# Altium schematic sheet pwr.SchDoc
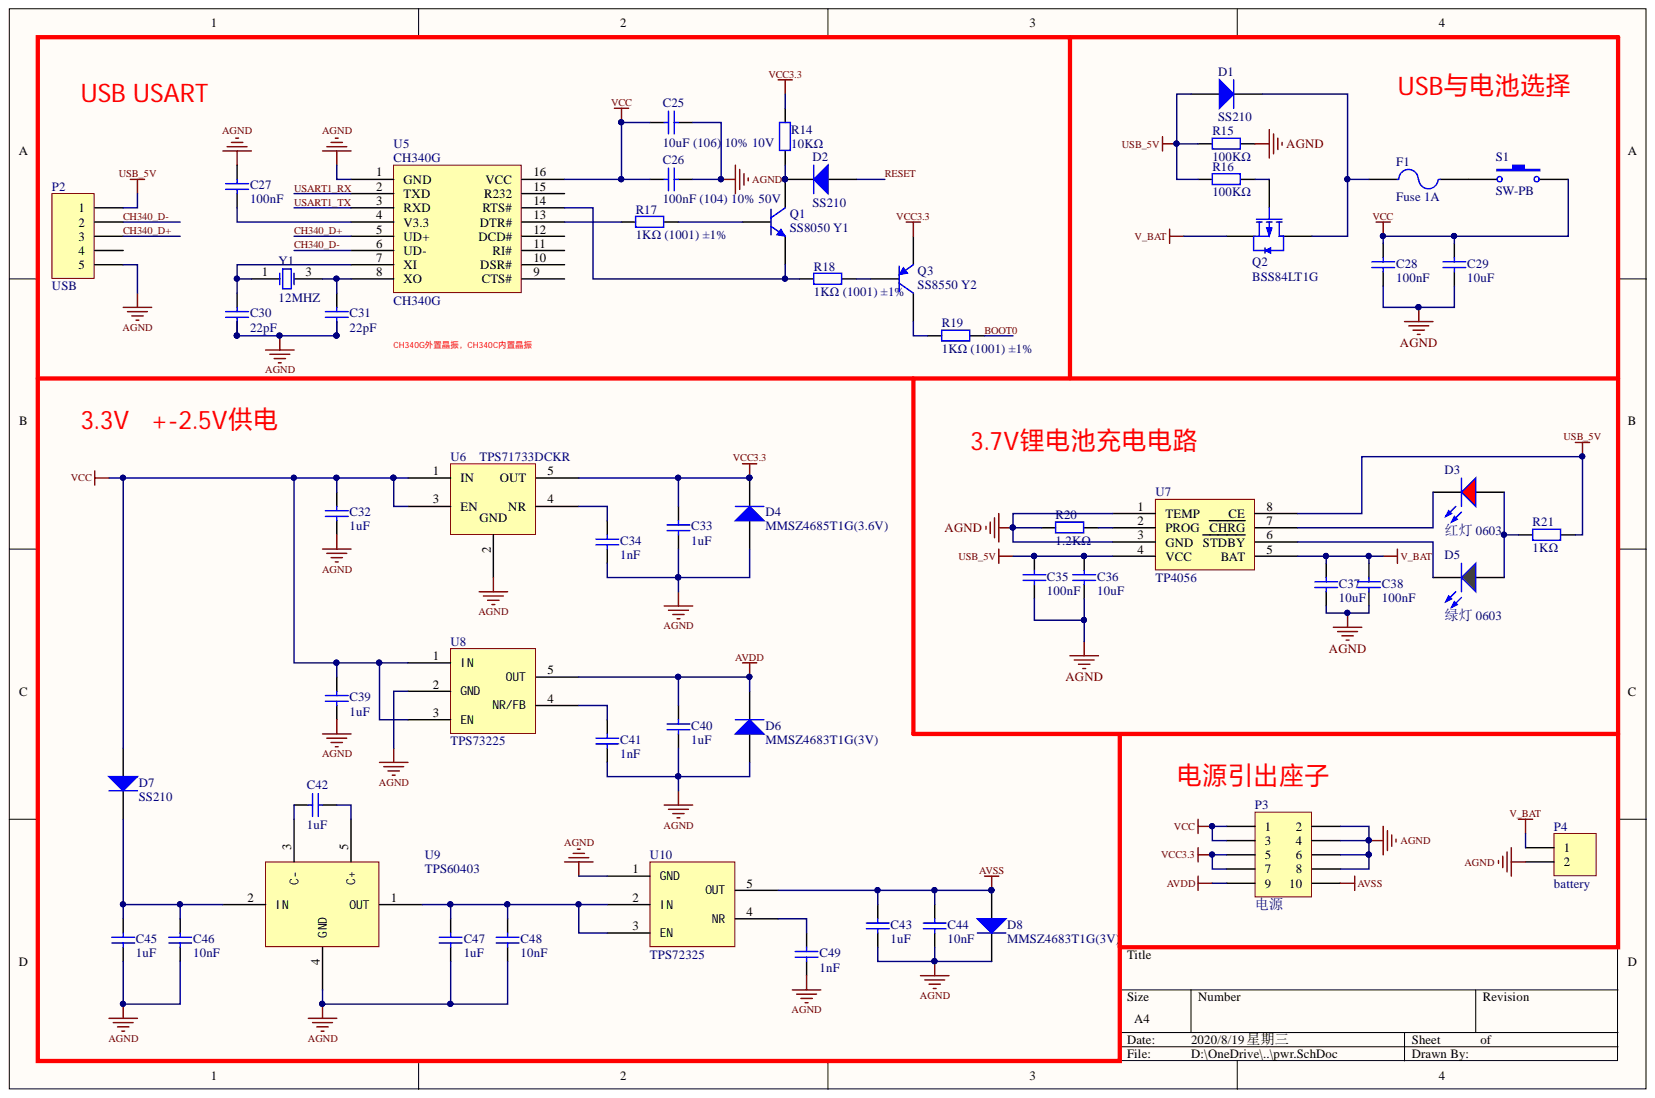
[diagram p0: pwr.SchDoc]

## Parts
C25  10uF (106) 10% 10V  [0402_C]
C26  100nF (104) 10% 50V  [0402_C]
C27  100nF  [0402_C]
C28  100nF  [0402_C]
C29  10uF  [0402_C]
C30  22pF  [0402_C]
C31  22pF  [0402_C]
C32  1uF  [0402_C]
C33  1uF  [0402_C]
C34  1nF  [0402_C]
C35  100nF  [0402_C]
C36  10uF  [0402_C]
C37  10uF  [0402_C]
C38  100nF  [0402_C]
C39  1uF  [0402_C]
C40  1uF  [0402_C]
C41  1nF  [0402_C]
C42  1uF  [0402_C]
C43  1uF  [0402_C]
C44  10nF  [0402_C]
C45  1uF  [0402_C]
C46  10nF  [0402_C]
C47  1uF  [0402_C]
C48  10nF  [0402_C]
C49  1nF  [0402_C]
D1  SS210  [SMA(DO-214AC)_S1]
D2  SS210  [SMA(DO-214AC)_S1]
D3  红灯 0603  Package: 0603  [0603_LED_S1]
D4  MMSZ4685T1G(3.6V)  [SOD-123]
D5  绿灯 0603  Package: 0603  [0603_LED_S1]
D6  MMSZ4683T1G(3V)  [SOD-123]
D7  SS210  [SMA(DO-214AC)_S1]
D8  MMSZ4683T1G(3V)  [SOD-123]
F1  Fuse 1A  [0805_R]
P2  USB  [MICRO-USB]
P3  电源  [HDR2X5]
P4  battery  [HDR1X2]
Q1  SS8050 Y1  [SOT-23(SOT-23-3)]
Q2  BSS84LT1G  [SOT-23(SOT-23-3)]
Q3  SS8550 Y2  [SOT-23(SOT-23-3)]
R14  10KΩ  [0402_R]
R15  100KΩ  [0402_R]
R16  100KΩ  [0402_R]
R17  1KΩ (1001) ±1%  [0402_R]
R18  1KΩ (1001) ±1%  [0402_R]
R19  1KΩ (1001) ±1%  [0402_R]
R20  1.2KΩ  [0402_R]
R21  1KΩ  [0402_R]
S1  SW-PB  [KEY_5.8*5.8]
U5  CH340G  [SOIC-16_150mil]
U6  TPS71733DCKR  [SC-70-5]
U7  TP4056  [SOP-8_EP_150mil]
U8  TPS73225  [SOT-23-5]
U9  TPS60403  [SOT-23-5]
U10  TPS72325  [SOT-23-5]
Y1  12MHZ  [SMD-3225_4P]

## Nets
AGND: C25.2 C26.2 C27.2 C28.1 C29.1 C30.1 C31.1 C32.1 C33.1 C34.1 C35.1 C36.1 C37.1 C38.1 C39.1 C40.1 C41.1 C43.2 C44.1 C45.2 C46.1 C47.2 C48.1 C49.1 D4.1 D6.1 D8.2 P2.5 P3.2 P3.4 P3.6 P3.8 P4.2 R15.2 R20.1 U5.1(GND) U6.2 U7.1(TEMP) U7.3(GND) U8.2(GND) U9.4(GND) U10.1(GND)
AVDD: C40.2 D6.2 P3.9 U8.5(OUT)
AVSS: C43.1 C44.2 D8.1 P3.10 U10.5(OUT)
USB_5V: C35.2 C36.2 D1.1 P2.1 R15.1 R16.1 R21.2 U7.4(VCC) U7.8(CE)
V_BAT: C37.2 C38.2 P4.1 Q2.2 U7.5(BAT)
VCC: C25.1 C26.1 C28.2 C29.2 C32.2 C39.2 D7.1 P3.1 P3.3 S1.2 U5.16(VCC) U6.1(IN) U6.3(EN) U8.1(IN) U8.3(EN)
VCC3.3: C33.2 D4.2 P3.5 P3.7 Q3.2 R14.1 U6.5(OUT)
BOOT0: R19.2
CH340_D+: P2.3 U5.5(UD+)
CH340_D-: P2.2 U5.6(UD-)
RESET: D2.1
USART1_RX: U5.2(TXD)
USART1_TX: U5.3(RXD)
NetC27_1: C27.1 U5.4(V3.3)
NetC30_2: C30.2 U5.7(XI) Y1.1
NetC31_2: C31.2 U5.8(XO) Y1.3
NetC34_2: C34.2 U6.4(NR)
NetC41_2: C41.2 U8.4(NR/FB)
NetC42_1: C42.1 U9.5(C+)
NetC42_2: C42.2 U9.3(C-)
NetC45_1: C45.1 C46.2 D7.2 U9.2(IN)
NetC47_1: C47.1 C48.2 U9.1(OUT) U10.2(IN) U10.3(EN)
NetC49_2: C49.2 U10.4(NR)
NetD1_2: D1.2 F1.1 Q2.3
NetD2_2: D2.2 Q1.3 R14.2
NetD3_A: D3.A D5.A R21.1
NetD3_K: D3.K U7.7(CHRG)
NetD5_K: D5.K U7.6(STDBY)
NetF1_2: F1.2 S1.1
NetQ1_1: Q1.1 R17.2
NetQ1_2: Q1.2 R18.2 U5.14(RTS#)
NetQ2_1: Q2.1 R16.2
NetQ3_1: Q3.1 R18.1
NetQ3_3: Q3.3 R19.1
NetR17_1: R17.1 U5.13(DTR#)
NetR20_2: R20.2 U7.2(PROG)

Not connected: P2 4; U5 9(CTS#) 10(DSR#) 11(RI#) 12(DCD#) 15(R232)

## Library data of the parts
C25 = 0603 10uF (106) 10% 10V: 贴片电容; Component Kind: Standard; ComponentLink1Description: 供应商链接; ComponentLink1URL: http://www.szlcsc.com/product/details_20411.html; Library Name: Miscellaneous Devices LC.IntLib; Package: 0603; Supplier: LC; SuppliersPartNumber: C19702
C26 C27 = 0603 100nF (104) 10% 50V: 贴片电容; Component Kind: Standard; ComponentLink1Description: 供应商链接; ComponentLink1URL: http://www.szlcsc.com/product/details_15331.html; Library Name: Miscellaneous Devices LC.IntLib; Package: 0603; Supplier: LC; SuppliersPartNumber: C14663
C28 C35 C38 = 0402 100nF (104) 10% 16V: 贴片电容; Component Kind: Standard; ComponentLink1Description: 供应商链接; ComponentLink1URL: http://www.szlcsc.com/product/details_1877.html; Library Name: Miscellaneous Devices LC.IntLib; Package: 0402; Supplier: LC; SuppliersPartNumber: C1525
C29 C36 C37 = 0402 10uF (106) 20% 6.3V: 贴片电容; Component Kind: Standard; ComponentLink1Description: 供应商链接; ComponentLink1URL: http://www.szlcsc.com/product/details_16204.html; Library Name: Miscellaneous Devices LC.IntLib; Package: 0402; Supplier: LC; SuppliersPartNumber: C15525
C30 C31 = 0603 22pF (220) 5% 50V: 贴片电容; Component Kind: Standard; ComponentLink1Description: 供应商链接; ComponentLink1URL: http://www.szlcsc.com/product/details_2005.html; Library Name: Miscellaneous Devices LC.IntLib; Package: 0603; Supplier: LC; SuppliersPartNumber: C1653
C32 C33 C39 C40 C42 C43 C45 C47 = 0603 1uF (105) 10% 50V: 贴片电容; Component Kind: Standard; ComponentLink1Description: 供应商链接; ComponentLink1URL: http://www.szlcsc.com/product/details_16531.html; Library Name: Miscellaneous Devices LC.IntLib; Package: 0603; Supplier: LC; SuppliersPartNumber: C15849
C34 C41 C49 = 0603 1nF (102) 10% 50V: 贴片电容; Component Kind: Standard; ComponentLink1Description: 供应商链接; ComponentLink1URL: http://www.szlcsc.com/product/details_1940.html; Library Name: Miscellaneous Devices LC.IntLib; Package: 0603; Supplier: LC; SuppliersPartNumber: C1588
C44 C46 C48 = 0603 10nF (103) 10% 50V: 贴片电容; Component Kind: Standard; ComponentLink1Description: 供应商链接; ComponentLink1URL: http://www.szlcsc.com/product/details_58143.html; Library Name: Miscellaneous Devices LC.IntLib; Package: 0603; Supplier: LC; SuppliersPartNumber: C57112
D1 D2 D7 = SMA(DO-214AC) SS210: 二极管; Component Kind: Standard; ComponentLink1Description: 供应商链接; ComponentLink1URL: http://www.szlcsc.com/product/details_15669.html; Library Name: Miscellaneous Devices LC.IntLib; Package: SMA(DO-214AC); Supplier: LC; SuppliersPartNumber: C14996
D3 = 0603 红灯: 发光二极管; Component Kind: Standard; ComponentLink1Description: 供应商链接; ComponentLink1URL: http://www.szlcsc.com/product/details_2659.html; Library Name: Miscellaneous Devices LC.IntLib; Supplier: LC; SuppliersPartNumber: C2286
D4 D6 D8 = SOD-123 B5819W  SL: 肖特基二极管; Component Kind: Standard; ComponentLink1Description: 供应商链接; ComponentLink1URL: http://www.szlcsc.com/product/details_9093.html; Library Name: Miscellaneous Devices LC.IntLib; Package: SOD-123; Supplier: LC; SuppliersPartNumber: C8598
D5 = 0603 绿灯: 发光二极管; Component Kind: Standard; ComponentLink1Description: 供应商链接; ComponentLink1URL: http://www.szlcsc.com/product/details_73152.html; Library Name: Miscellaneous Devices LC.IntLib; Supplier: LC; SuppliersPartNumber: C72043
F1 = Fuse 2: Fuse; Component Kind: Standard; LatestRevisionDate: 17-Jul-2002; LatestRevisionNote: Re-released for DXP Platform.; Library Name: Miscellaneous Devices.IntLib; PackageDescription: Thru-Hole; 2 Leads; PackageReference: PIN-W2/E2.8; Published: 8-Jun-2000; Simulation: FUSE; Publisher: Altium Limited
P2 = Header 5: Header, 5-Pin; Component Kind: Standard; LatestRevisionDate: 17-Jul-2002; LatestRevisionNote: Re-released for DXP Platform.; Library Name: Miscellaneous Connectors.IntLib; Signal Integrity: Connector; Publisher: Altium Limited
P3 = Header 5X2: Header, 5-Pin, Dual row; Component Kind: Standard; LatestRevisionDate: 17-Jul-2002; LatestRevisionNote: Re-released for DXP Platform.; Library Name: Miscellaneous Connectors.IntLib; Signal Integrity: Connector; Publisher: Altium Limited
P4 = Header 2: Header, 2-Pin; Component Kind: Standard; LatestRevisionDate: 17-Jul-2002; LatestRevisionNote: Re-released for DXP Platform.; Library Name: Miscellaneous Connectors.IntLib; Signal Integrity: Connector; Publisher: Altium Limited
Q1 = SOT-23 SS8050 Y1: 三极管; Component Kind: Standard; ComponentLink1Description: 供应商链接; ComponentLink1URL: http://www.szlcsc.com/product/details_2507.html; Library Name: Miscellaneous Devices LC.IntLib; Package: SOT-23; Supplier: LC; SuppliersPartNumber: C2150
Q2 = BSS84LT1G: MOS(场效应管); Component Kind: Standard; ComponentLink1Description: 供应商链接; ComponentLink1URL: http://www.szlcsc.com/product/details_8986.html; Library Name: Miscellaneous Devices LC.IntLib; Package: SOT-23(SOT-23-3); Supplier: LC; SuppliersPartNumber: C8492
Q3 = SOT-23 SS8550 Y2: 三极管; Component Kind: Standard; ComponentLink1Description: 供应商链接; ComponentLink1URL: http://www.szlcsc.com/product/details_9037.html; Library Name: Miscellaneous Devices LC.IntLib; Package: SOT-23; Supplier: LC; SuppliersPartNumber: C8542
R14 R17-R19 = 0603 1KΩ (1001) 1%: 贴片电阻; Component Kind: Standard; ComponentLink1Description: 供应商链接; ComponentLink1URL: http://www.szlcsc.com/product/details_21904.html; Library Name: Miscellaneous Devices LC.IntLib; Package: 0603; Supplier: LC; SuppliersPartNumber: C21190
R15 R16 = 0402 100KΩ (1003) 1%: 贴片电阻; Component Kind: Standard; ComponentLink1Description: 供应商链接; ComponentLink1URL: http://www.szlcsc.com/product/details_26484.html; Library Name: Miscellaneous Devices LC.IntLib; Package: 0402; Supplier: LC; SuppliersPartNumber: C25741
R20 = 0402 1.2KΩ (1201) 1%: 贴片电阻; Component Kind: Standard; ComponentLink1Description: 供应商链接; ComponentLink1URL: http://www.szlcsc.com/product/details_26605.html; Library Name: Miscellaneous Devices LC.IntLib; Package: 0402; Supplier: LC; SuppliersPartNumber: C25862
R21 = 0402 1KΩ (1001) 1%: 贴片电阻; Component Kind: Standard; ComponentLink1Description: 供应商链接; ComponentLink1URL: http://www.szlcsc.com/product/details_12256.html; Library Name: Miscellaneous Devices LC.IntLib; Package: 0402; Supplier: LC; SuppliersPartNumber: C11702
S1 = SW-PB: Switch; Component Kind: Standard; LatestRevisionDate: 17-Jul-2002; LatestRevisionNote: Re-released for DXP Platform.; Library Name: Miscellaneous Devices.IntLib; PackageDescription: Switch; 2 Leads; PackageReference: SPST-2; Published: 24-Mar-1999; Publisher: Altium Limited
U5 = CH340G_[C14267]: USB; Component Kind: Standard; ComponentLink1Description: 供应商链接; ComponentLink1URL: http://www.szlcsc.com/product/details_14927.html; Package: SOIC-16_150mil; Supplier: LC; SuppliersPartNumber: C14267; Library Name: TI Voltage Controlled Oscillator.IntLib
U7 = TP4056_[C16581]: 电池电源管理; Component Kind: Standard; ComponentLink1Description: 供应商链接; ComponentLink1URL: http://www.szlcsc.com/product/details_17264.html; Library Name: Miscellaneous Devices LC.IntLib; Package: SOP-8_EP_150mil; Supplier: LC; SuppliersPartNumber: C16581
Y1 = SMD-3225_4P  12MHZ 20PF 10PPM: 贴片晶振; Component Kind: Standard; ComponentLink1Description: 供应商链接; ComponentLink1URL: http://www.szlcsc.com/product/details_9522.html; Library Name: Miscellaneous Devices LC.IntLib; Package: SMD-3225_4P; Supplier: LC; SuppliersPartNumber: C9002
U6 = TPS71733DCKR: Component Kind: Standard; Library Name: EEG.SchLib
U8 = TPS73225: Component Kind: Standard; Library Name: EEG.SchLib
U9 = TPS60403: Component Kind: Standard; Library Name: EEG.SchLib
U10 = TPS72325: Component Kind: Standard; Library Name: EEG.SchLib
